# Altium schematic sheet POWER.SchDoc(POWER)
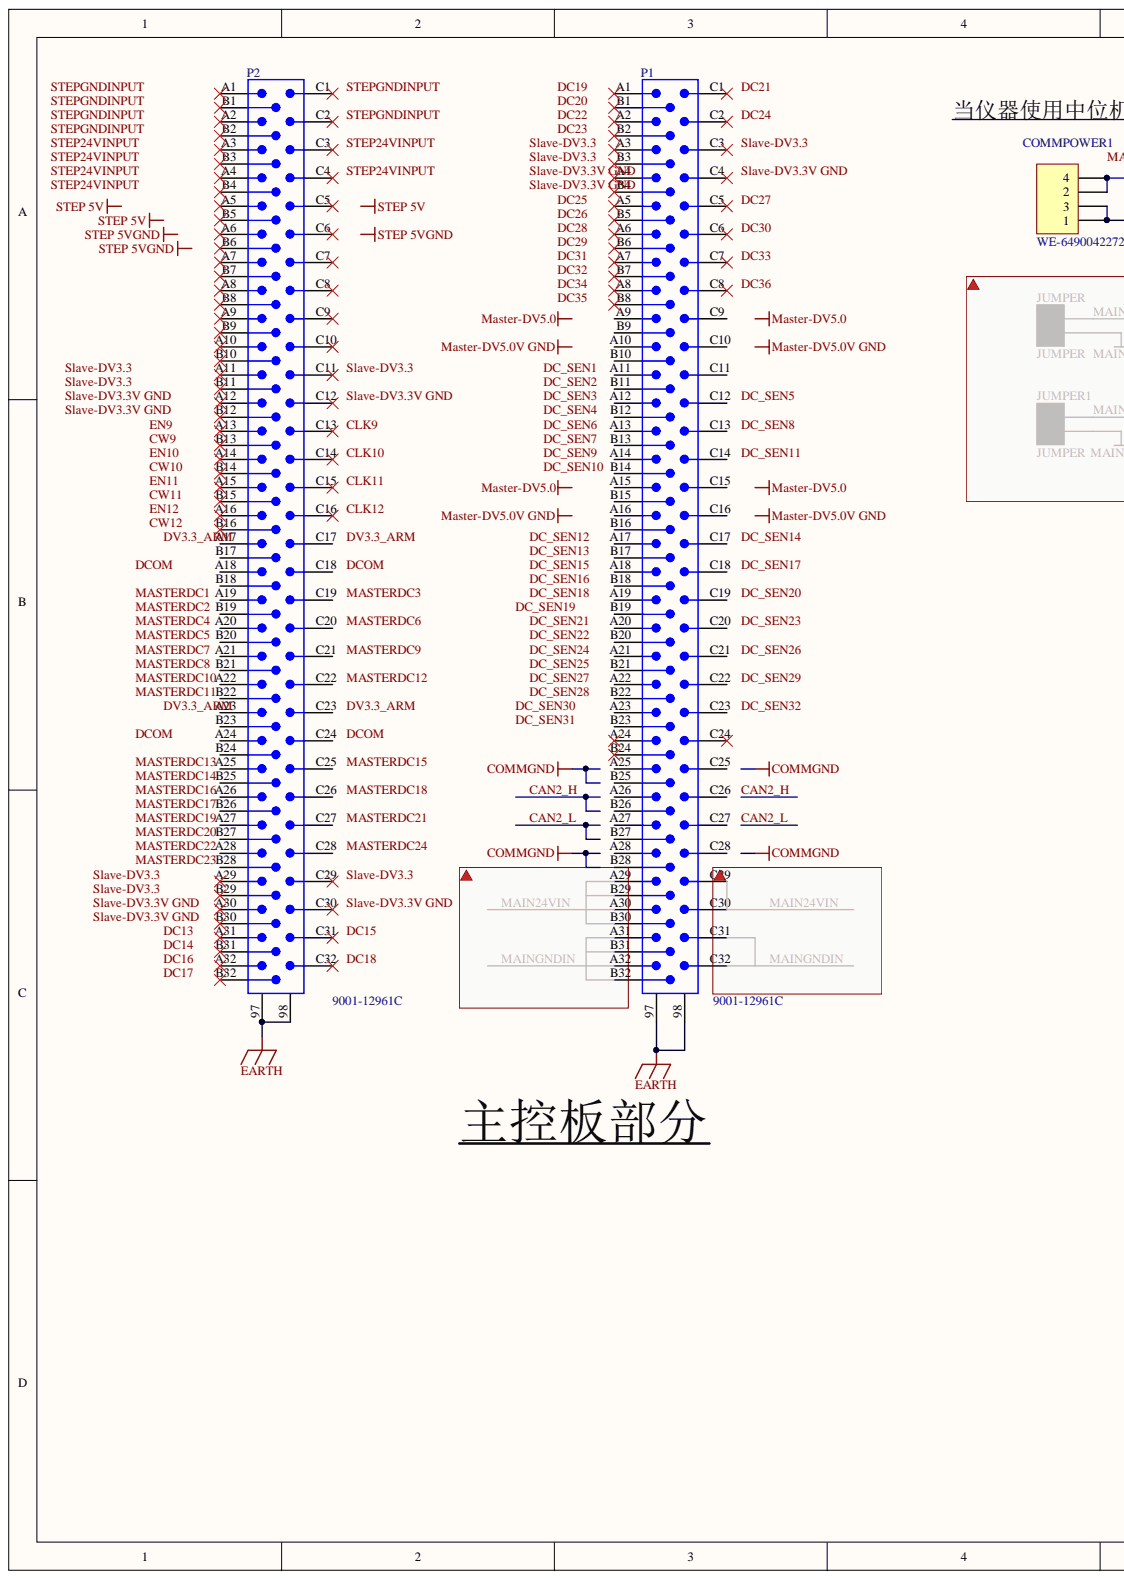
[diagram p0: POWER.SchDoc, left]
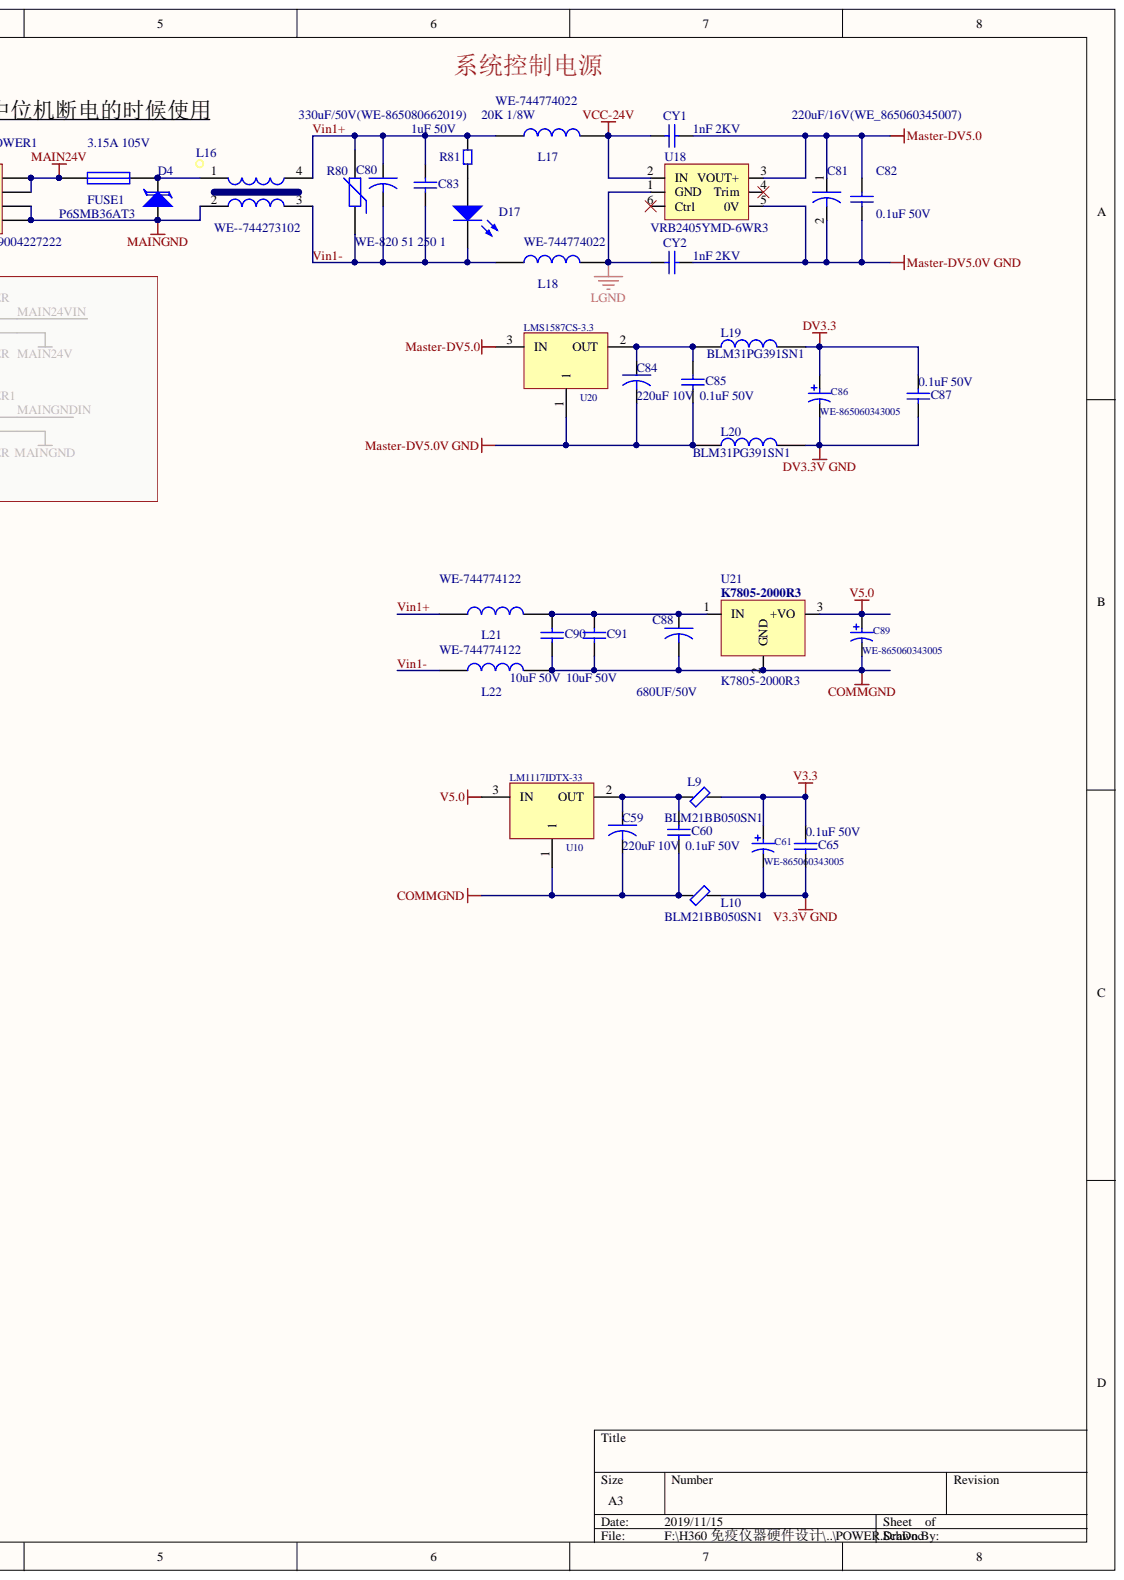
[diagram p1: POWER.SchDoc, right]

## Parts
C59  220uF 10V  [CAPDCASE_E]
C60  0.1uF 50V  [C0603_104/50V]
C61  WE-865060343005  [CAPF63_100UF/16V]
C65  0.1uF 50V  [C0603_104/50V]
C80  330uF/50V(WE-865080662019)  [WE-CE-12.5*14-865080662019(331/50V)]
C81  220uF/16V(WE_865060345007)  [WE-ASLL-6.3*7.7-865060345007(220/16V)]
C82  0.1uF 50V  [C0805_104/50V]
C83  1uF 50V  [C0805_105/50V]
C84  220uF 10V  [CAPDCASE_E]
C85  0.1uF 50V  [C0603_104/50V]
C86  WE-865060343005  [CAPF63_100UF/16V]
C87  0.1uF 50V  [C0603_104/50V]
C88  680UF/50V  [WE-CE-16*17-865060663014(681/50V)]
C89  WE-865060343005  [CAPF63_100UF/16V]
C90  10uF 50V  [C0805_106/50V]
C91  10uF 50V  [C0805_106/50V]
COMMPOWER1  WE-649004227222  [M5557D420P2X2-90]
CY1  1nF 2KV  [C1206_102/2KV]
CY2  1nF 2KV  [C1206_102/2KV]
D4  P6SMB36AT3  [DIODESMB_DIODESMB]
D17  LED2  [LED_0805]  (value not drawn)
FUSE1  3.15A 105V  [FUSE2410ZUO]
L9  BLM21BB050SN1  [0805_BLM21BB050SN1]
L10  BLM21BB050SN1  [0805_BLM21BB050SN1]
L16  WE--744273102  [WE-SL5-SMD_744273501]
L17  WE-744774022  [WE-PD-SMD5848-2.2uH/4.6A_744774022]
L18  WE-744774022  [WE-PD-SMD5848-2.2uH/4.6A_744774022]
L19  BLM31PG391SN1  [1206_BLM31PG391SN1]
L20  BLM31PG391SN1  [1206_BLM31PG391SN1]
L21  WE-744774122  [WE-PD-SMD5848-22uH/1.28A_744774122 1]
L22  WE-744774122  [WE-PD-SMD5848-22uH/1.28A_744774122 1]
P1  9001-12961C  [CNVME96-male]
P2  9001-12961C  [CNVME96-male]
R80  WE-820 51 250 1  [WE-VD-10_820512501]
R81  20K 1/8W  [RES0805_203]
U10  LM1117IDTX-33  [78M05_TO252]
U18  VRB2405YMD-6WR3  [VRB2405YMD-10WR3]
U20  LMS1587CS-3.3  [TO-263_LMS1587CS-3.3]
U21  K7805-2000R3  [MORNSUN-K7805-2000R3]

## Nets
COMMGND: C59.2 C60.2 C88.2 C89.2 C90.2 C91.2 L10.1 L22.2 U10.1 U21.2
DV3.3: C86.1 C87.1 L19.2
DV3.3V GND: C86.2 C87.2 L20.2
EARTH: P1.97 P1.98 P2.97 P2.98
LGND: CY2.1 L18.2 U18.1(GND)
MAIN24V: COMMPOWER1.2 COMMPOWER1.4 FUSE1.1
MAINGND: COMMPOWER1.1 COMMPOWER1.3 D4.1 L16.2
MASTER-DV5.0: C81.1 C82.1 CY1.2 U18.3(VOUT+) U20.3
MASTER-DV5.0V GND: C81.2 C82.2 C84.2 C85.2 CY2.2 L20.1 U18.5(0V) U20.1
V3.3: C61.1 C65.1 L9.2
V3.3V GND: C61.2 C65.2 L10.2
V5.0: C89.1 U10.3 U21.3
VCC-24V: CY1.1 L17.2 U18.2(IN)
VIN1+: C80.1 C83.1 L16.4 L17.1 L21.1 R80.2 R81.2
VIN1-: C80.2 C83.2 D17.2 L16.3 L18.1 L22.1 R80.1
NetC59_1: C59.1 C60.1 L9.1 U10.2
NetC84_1: C84.1 C85.1 L19.1 U20.2
NetC88_1: C88.1 C90.1 C91.1 L21.2 U21.1
NetD4_2: D4.2 FUSE1.2 L16.1
NetD17_1: D17.1 R81.1
CAN2_H:
CAN2_L:
CLK9:
CLK10:
CLK11:
CLK12:
CW9:
CW10:
CW11:
CW12:
DC13:
DC14:
DC15:
DC16:
DC17:
DC18:
DC19:
DC20:
DC21:
DC22:
DC23:
DC24:
DC25:
DC26:
DC27:
DC28:
DC29:
DC30:
DC31:
DC32:
DC33:
DC34:
DC35:
DC36:
DC_SEN1:
DC_SEN2:
DC_SEN3:
DC_SEN4:
DC_SEN5:
DC_SEN6:
DC_SEN7:
DC_SEN8:
DC_SEN9:
DC_SEN10:
DC_SEN11:
DC_SEN12:
DC_SEN13:
DC_SEN14:
DC_SEN15:
DC_SEN16:
DC_SEN17:
DC_SEN18:
DC_SEN19:
DC_SEN20:
DC_SEN21:
DC_SEN22:
DC_SEN23:
DC_SEN24:
DC_SEN25:
DC_SEN26:
DC_SEN27:
DC_SEN28:
DC_SEN29:
DC_SEN30:
DC_SEN31:
DC_SEN32:
DCOM:
DV3.3_ARM:
EN9:
EN10:
EN11:
EN12:
MASTERDC1:
MASTERDC2:
MASTERDC3:
MASTERDC4:
MASTERDC5:
MASTERDC6:
MASTERDC7:
MASTERDC8:
MASTERDC9:
MASTERDC10:
MASTERDC11:
MASTERDC12:
MASTERDC13:
MASTERDC14:
MASTERDC15:
MASTERDC16:
MASTERDC17:
MASTERDC18:
MASTERDC19:
MASTERDC20:
MASTERDC21:
MASTERDC22:
MASTERDC23:
MASTERDC24:
SLAVE-DV3.3:
SLAVE-DV3.3V GND:
STEP24VINPUT:
STEP 5V:
STEP 5VGND:
STEPGNDINPUT:

Not connected: P1 A1 A2 A3 A4 A5 A6 A7 A8 A9 A10 A11 A12 A13 A14 A15 A16 A17 A18 A19 A20 A21 A22 A23 A24 A25 A26 A27 A28 A29 A30 A31 A32 B1 B2 B3 B4 B5 B6 B7 B8 B9 B10 B11 B12 B13 B14 B15 B16 B17 B18 B19 B20 B21 B22 B23 B24 B25 B26 B27 B28 B29 B30 B31 B32 C1 C2 C3 C4 C5 C6 C7 C8 C9 C10 C11 C12 C13 C14 C15 C16 C17 C18 C19 C20 C21 C22 C23 C24 C25 C26 C27 C28 C29 C30 C31 C32; P2 A1 A2 A3 A4 A5 A6 A7 A8 A9 A10 A11 A12 A13 A14 A15 A16 A17 A18 A19 A20 A21 A22 A23 A24 A25 A26 A27 A28 A29 A30 A31 A32 B1 B2 B3 B4 B5 B6 B7 B8 B9 B10 B11 B12 B13 B14 B15 B16 B17 B18 B19 B20 B21 B22 B23 B24 B25 B26 B27 B28 B29 B30 B31 B32 C1 C2 C3 C4 C5 C6 C7 C8 C9 C10 C11 C12 C13 C14 C15 C16 C17 C18 C19 C20 C21 C22 C23 C24 C25 C26 C27 C28 C29 C30 C31 C32; U18 4(Trim) 6(Ctrl)

## Library data of the parts
C59 C84 = CAPACITOR: Capacitor, Tantalum, 220uF 10% 10V MCCT-D (7343-31); Component Kind: Standard
C60 C65 C85 C87 = Cap Semi: SAMSUNG  MULTILAYER  CERAMIC 5%; Value: 105; Component Kind: Standard; LatestRevisionDate: 29-May-2009; LatestRevisionNote: IPC-7351 Footprint Added.; Library Name: Miscellaneous Devices.IntLib; PackageDescription: Chip Capacitor; PackageReference: C1206; Published: 8-Jun-2000; Signal Integrity: Cap Semi; Simulation: CAP; Publisher: Altium Limited
C61 C86 C89 = CAP_POLAR_1: WCAP-AS5H 16V Long Life  100uF 16V; Component Kind: Standard; PCB3D: Cap5x6_8; Library Name: SpiritLevel_2E.SchLib
C80 = CAPACITOR: WCAP-ASLI 50/63V Low Impedance   WE---865080662019; Component Kind: Standard
C81 = CAPACITOR: WCAP-ASLI 50/63V Low Impedance; Component Kind: Standard
C82 C83 C90 C91 = Cap Semi: Capacitor (Semiconductor SIM Model); Value: 105; Component Kind: Standard; LatestRevisionDate: 29-May-2009; LatestRevisionNote: IPC-7351 Footprint Added.; Library Name: Miscellaneous Devices.IntLib; PackageDescription: Chip Capacitor; PackageReference: C1206; Published: 8-Jun-2000; Signal Integrity: Cap Semi; Simulation: CAP; Publisher: Altium Limited
C88 = CAPACITOR: WCAP-ASLI 50V/63V Low Impedance  Long Life  WE---865060663014; Component Kind: Standard
COMMPOWER1 = Header 4H: Header, 4-Pin, Right Angle; Component Kind: Standard; LatestRevisionDate: 17-Jul-2002; LatestRevisionNote: Re-released for DXP Platform.; Library Name: 2015.10.1.SCHLIB; Signal Integrity: Connector; Publisher: Altium Limited
CY1 CY2 = Cap Semi: C1206KKX7RDBB102; Value: 105; Component Kind: Standard; LatestRevisionDate: 29-May-2009; LatestRevisionNote: IPC-7351 Footprint Added.; Library Name: Miscellaneous Devices.IntLib; PackageDescription: Chip Capacitor; PackageReference: C1206; Published: 8-Jun-2000; Signal Integrity: Cap Semi; Simulation: CAP; Publisher: Altium Limited
D4 = DIODE OVERVOLTAGE: Component Kind: Standard
D17 = LED2: Typical  GREEN,  GaAs LED; Component Kind: Standard; LatestRevisionDate: 17-Jul-2002; LatestRevisionNote: Re-released for DXP Platform.; Library Name: Miscellaneous Devices.IntLib; PackageDescription: SM LED; 2 Flat Leads; PackageReference: 3.2X1.6X1.1; PackageVersion: Feb-2002; Published: 8-Jun-2000; Simulation: LED2; Publisher: Altium Limited
FUSE1 = FUSE1: Fuse; Component Kind: Standard
L9 L10 = FERRITE BEAD: Component Kind: Standard
L16 = WE-SL5 HC: SMD Common Mode Line Filter  Z=2X500Ohm RDC=0.006Ohm I=5.0A WE--744273102; Component Kind: Standard; Library Name: mainboard_v1.1.SCHLIB
L17 L18 = INDUCTOR: WE-PD2 SMD Shielded Power Inductor  2.2uH; Component Kind: Standard; Library Name: 2015.10.1.SCHLIB
L19 L20 = INDUCTOR: On-Board Type (DC) EMI Suppression Filters; Component Kind: Standard; Library Name: 2015.10.1.SCHLIB
L21 L22 = INDUCTOR: WE-PD2 SMD Shielded Power Inductor  22uH; Component Kind: Standard; Library Name: 2015.10.1.SCHLIB
P1 P2 = CNVME96: 96-Pin Connector (3x32 2.54mm grid); Text Field6: Alternate Layout Available; Text Field7: Published 14-Feb-2002; Component Kind: Standard; Library Name: H200MasterBoard.SchLib
R80 = Res Varistor: Varistor (Voltage-Sensitive Resistor); Code_IPC: RESC2012; Component Kind: Standard; LatestRevisionDate: 29-May-2009; LatestRevisionNote: IPC-7351 Footprint Added.; Library Name: 2015.10.1.SCHLIB; PackageDescription: Chip Resistor 0805 Case Size; PackageReference: 6-0805; PackageVersion: Mar-2003; Published: 24-Mar-1999; Publisher: Altium Limited
R81 = RES2: YAGEO Chip  1%; Component Kind: Standard
U10 U20 = LM1084IS-ADJ: 5A Low Dropout Possitive Regulators; Component Kind: Standard; PCB3D: to263; Library Name: SpiritLevel_2E.SchLib
U18 = VRB2403LD-20WR3: Component Kind: Standard; Library Name: 2015.10.1.SCHLIB
U21 = K78XX-2000R3: MORNSUN DC-DC; Component Kind: Standard; Library Name: H200 Slave machine.SCHLIB
